# Altium schematic sheet PowerLatch.SchDoc(PowerLatch)
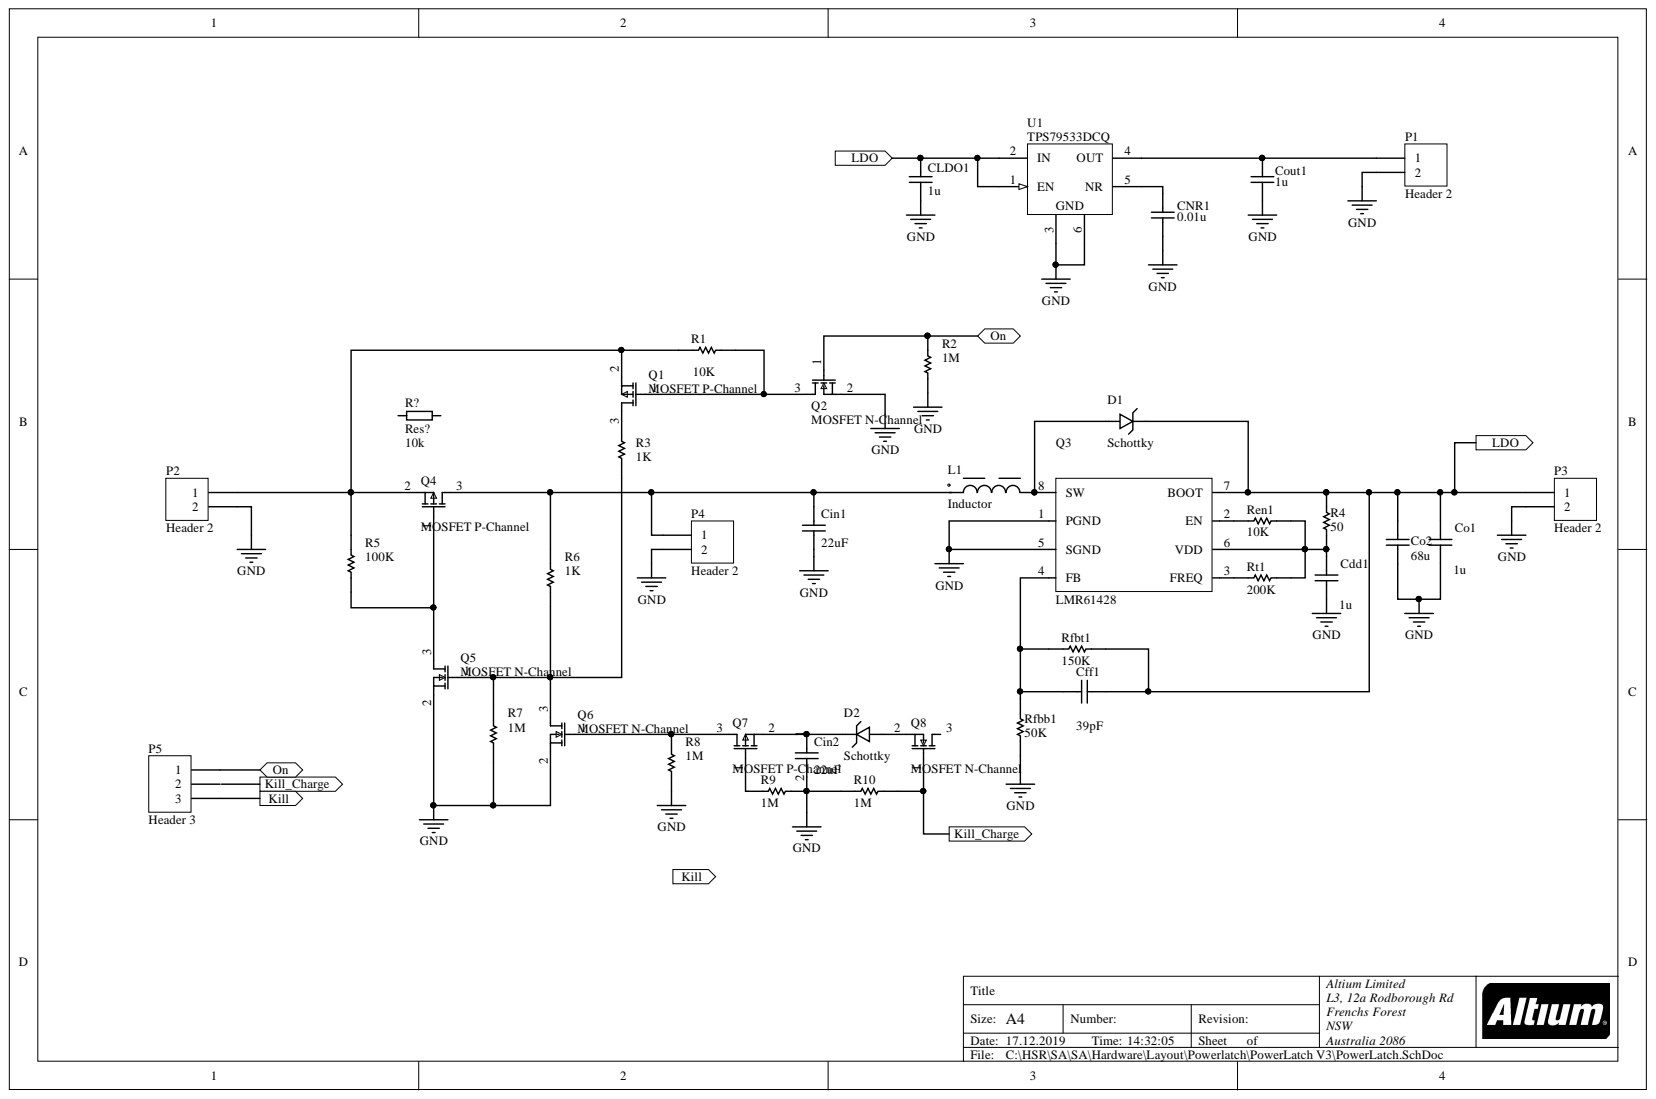
[diagram p0: PowerLatch.SchDoc]

## Parts
Cdd1  value 1u  [RESC1608X06N]  (hidden comment: Cap)
Cff1  value 39pF  [RESC1608X06N]  (hidden comment: Cap)
Cin1  value 22uF  [CAPMP7343X31N]  (hidden comment: Cap)
Cin2  value 22uF  [CAPMP7343X31N]  (hidden comment: Cap)
CLDO1  value 1u  [RESC1608X06N]  (hidden comment: Cap)
CNR1  value 0.01u  [RESC1608X06N]  (hidden comment: Cap)
Co1  value 1u  [CAPC0805]  (hidden comment: Cap)
Co2  value 68u  [CAP2812]  (hidden comment: Cap)
Cout1  value 1u  [RESC1608X06N]  (hidden comment: Cap)
D1  Schottky  [DIOM4326X23N]
D2  Schottky  [DIOM4326X23N]
L1  Inductor  [INDP100100X51N]
P1  Header 2  [PCBComponent_1]
P2  Header 2  [PCBComponent_1]
P3  Header 2  [PCBComponent_1]
P4  Header 2  [PCBComponent_1]
P5  Header 3  [HDR1X3]
Q1  MOSFET P-Channel  [SOT95P245X110-3N]
Q2  MOSFET N-Channel  [SOT95P245X110-3N]
Q3  LMR61428  [TSOP65P490X110-8N]
Q4  MOSFET P-Channel  [SOT95P245X110-3N]
Q5  MOSFET N-Channel  [SOT95P245X110-3N]
Q6  MOSFET N-Channel  [SOT95P245X110-3N]
Q7  MOSFET P-Channel  [SOT95P245X110-3N]
Q8  MOSFET N-Channel  [SOT95P245X110-3N]
R1  value 10K  [RESC1608X06N]  (hidden comment: Res1)
R2  value 1M  [RESC1608X06N]  (hidden comment: Res1)
R3  value 1K  [RESC1608X06N]  (hidden comment: Res1)
R4  value 50  [RESC1608X06N]  (hidden comment: Res1)
R5  value 100K  [RESC1608X06N]  (hidden comment: Res1)
R6  value 1K  [RESC1608X06N]  (hidden comment: Res1)
R7  value 1M  [RESC1608X06N]  (hidden comment: Res1)
R8  value 1M  [RESC1608X06N]  (hidden comment: Res1)
R9  value 1M  [RESC1608X06N]  (hidden comment: Res1)
R10  value 1M  [RESC1608X06N]  (hidden comment: Res1)
R?  Res?  value 10k
Ren1  value 10K  [RESC1608X06N]  (hidden comment: Res1)
Rfbb1  value 50K  [RESC1608X06N]  (hidden comment: Res1)
Rfbt1  value 150K  [RESC1608X06N]  (hidden comment: Res1)
Rt1  value 200K  [RESC1608X06N]  (hidden comment: Res1)
U1  TPS79533DCQ  [DCQ0006A_L]

## Ports
Kill, Kill_Charge, LDO, On

## Nets
GND: Cdd1.1 Cin1.2 Cin2.2 CLDO1.1 CNR1.1 Co1.1 Co2.2 Cout1.1 P1.2 P2.2 P3.2 P4.2 Q2.2 Q3.1(PGND) Q3.5(SGND) Q5.2 Q6.2 R2.1 R7.1 R8.1 R9.1 R10.2 Rfbb1.2 U1.3 U1.6
Kill: P5.3 ; port Kill
Kill_Charge: Q8.1 R10.1 ; port Kill_Charge
LDO: Cff1.1 CLDO1.2 Co1.2 Co2.1 D1.2 P3.1 Q3.7(BOOT) R4.1 Rfbt1.2 U1.1(EN) U1.2(IN) ; port LDO
On: P5.1 Q2.1 R2.2 ; port On
NetCdd1_2: Cdd1.2 Q3.6(VDD) R4.2 Ren1.2 Rt1.2
NetCff1_2: Cff1.2 Q3.4(FB) Rfbb1.1 Rfbt1.1
NetCin1_1: Cin1.1 L1.1 P4.1 Q4.3 R6.2
NetCin2_1: Cin2.1 D2.2 Q7.2
NetCNR1_2: CNR1.2 U1.5(NR)
NetCout1_2: Cout1.2 P1.1 U1.4(OUT)
NetD1_1: D1.1 L1.2 Q3.8(SW)
NetD2_1: D2.1 Q8.2
NetP2_1: P2.1 Q1.2 Q4.2 R1.2 R5.2
NetQ1_1: Q1.1 Q2.3 R1.1
NetQ1_3: Q1.3 R3.2
NetQ3_2: Q3.2(EN) Ren1.1
NetQ3_3: Q3.3(FREQ) Rt1.1
NetQ4_1: Q4.1 Q5.3 R5.1
NetQ5_1: Q5.1 Q6.3 R3.1 R6.1 R7.2
NetQ6_1: Q6.1 Q7.3 R8.2
NetQ7_1: Q7.1 R9.2

Not connected: P5 2; Q8 3; R? 1 2

## Library data of the parts
Cdd1 Cff1 Cin1 Cin2 CLDO1 CNR1 Co1 Co2 Cout1 = Cap: Capacitor; Simulation: CAP; Signal Integrity: Cap; Published: 8-Jun-2000; PackageReference: RAD-0.3; PackageDescription: Radial Cap, Thru-Hole; 2 Leads; 0.3 in Pin Spacing; Library Name: Miscellaneous Devices.IntLib; LatestRevisionNote: Re-released for DXP Platform.; LatestRevisionDate: 17-Jul-2002; Component Kind: Standard; Publisher: Altium Limited
P1-P4 = Header 2: Header, 2-Pin; Signal Integrity: Connector; Library Name: Miscellaneous Connectors.IntLib; LatestRevisionNote: Re-released for DXP Platform.; LatestRevisionDate: 17-Jul-2002; Component Kind: Standard; Publisher: Altium Limited
P5 = Header 3: Header, 3-Pin; Signal Integrity: Connector; Library Name: Miscellaneous Connectors.IntLib; LatestRevisionNote: Re-released for DXP Platform.; LatestRevisionDate: 17-Jul-2002; Component Kind: Standard; Publisher: Altium Limited
Q3 = LMR61428: Step-Up Voltage Regulator; Library Name: Schlib1.SchLib; Component Kind: Standard
R1-R10 Ren1 Rfbb1 Rfbt1 Rt1 = Res1: Resistor; Simulation: RESISTOR; Signal Integrity: Res; Published: 8-Jun-2000; PackageReference: AXIAL-0.3; PackageDescription: Axial Device, Thru-Hole; 2 Leads; 0.3 in Pin Spacing; Library Name: Miscellaneous Devices.IntLib; LatestRevisionNote: Re-released for DXP Platform.; LatestRevisionDate: 17-Jul-2002; Component Kind: Standard; Publisher: Altium Limited
U1 = CMP-0395-00363-2: Single Output High PSRR LDO, 500 mA, Fixed 3.3 V Output, 2.7 to 5.5 V Input, 6-pin SOT-223 (DCQ), Green (RoHS & no Sb/Br); Manufacturer: Texas Instruments; Vout Adj(Min)(V): 3.3; Vin(Min)(V): 2.7; Vin(Max)(V): 5.5; Vdo(Typ)(mV): 105; SubFamily: Single Channel LDO; Rating: Catalog; Iq(Typ)(mA): 0.26; Iout(Max)(A): 0.5; Accuracy(%): 2; Vout Adj(Max)(V): 3.3; PartNumber: TPS79533DCQ; PackageVersion: 4202109/D; PackageReference: DCQ0006A; PackageDescription: 6-Pin Plastic Small Outline, Body 3.5 x 6.5 mm, Pitch 1.27 mm; Mounting Technology: Surface Mount; Library Name: Altium Content Vault; Fixed Output Options(V): 3.3; Eco-Plan: Green (RoHS & no Sb/Br); DatasheetVersion: SLVS350H; ComponentLink3URL: http://www.ti.com/litv/pdf/mpds098c; ComponentLink3Description: Package Specification; ComponentLink2URL: http://www.ti.com/general/docs/lit/getliterature.tsp?genericPartNumber=TPS79533&fileType=pdf; ComponentLink2Description: Datasheet; ComponentLink1URL: http://www.ti.com/; ComponentLink1Description: Manufacturer URL; Component Kind: Standard
D1 D2 = Schottky: Library Name: Schlib1.SchLib; Component Kind: Standard
L1 = Inductor: Library Name: Schlib1.SchLib; Component Kind: Standard
Q1 Q4 Q7 = MOSFET P-Channel: Library Name: Schlib1.SchLib; Component Kind: Standard
Q2 Q5 Q6 Q8 = MOSFET N-Channel: Library Name: Schlib1.SchLib; Component Kind: Standard
R? = Res?: Library Name: Schlib1.SchLib; Component Kind: Standard
